# Altium schematic sheet RF.SchDoc(RF)
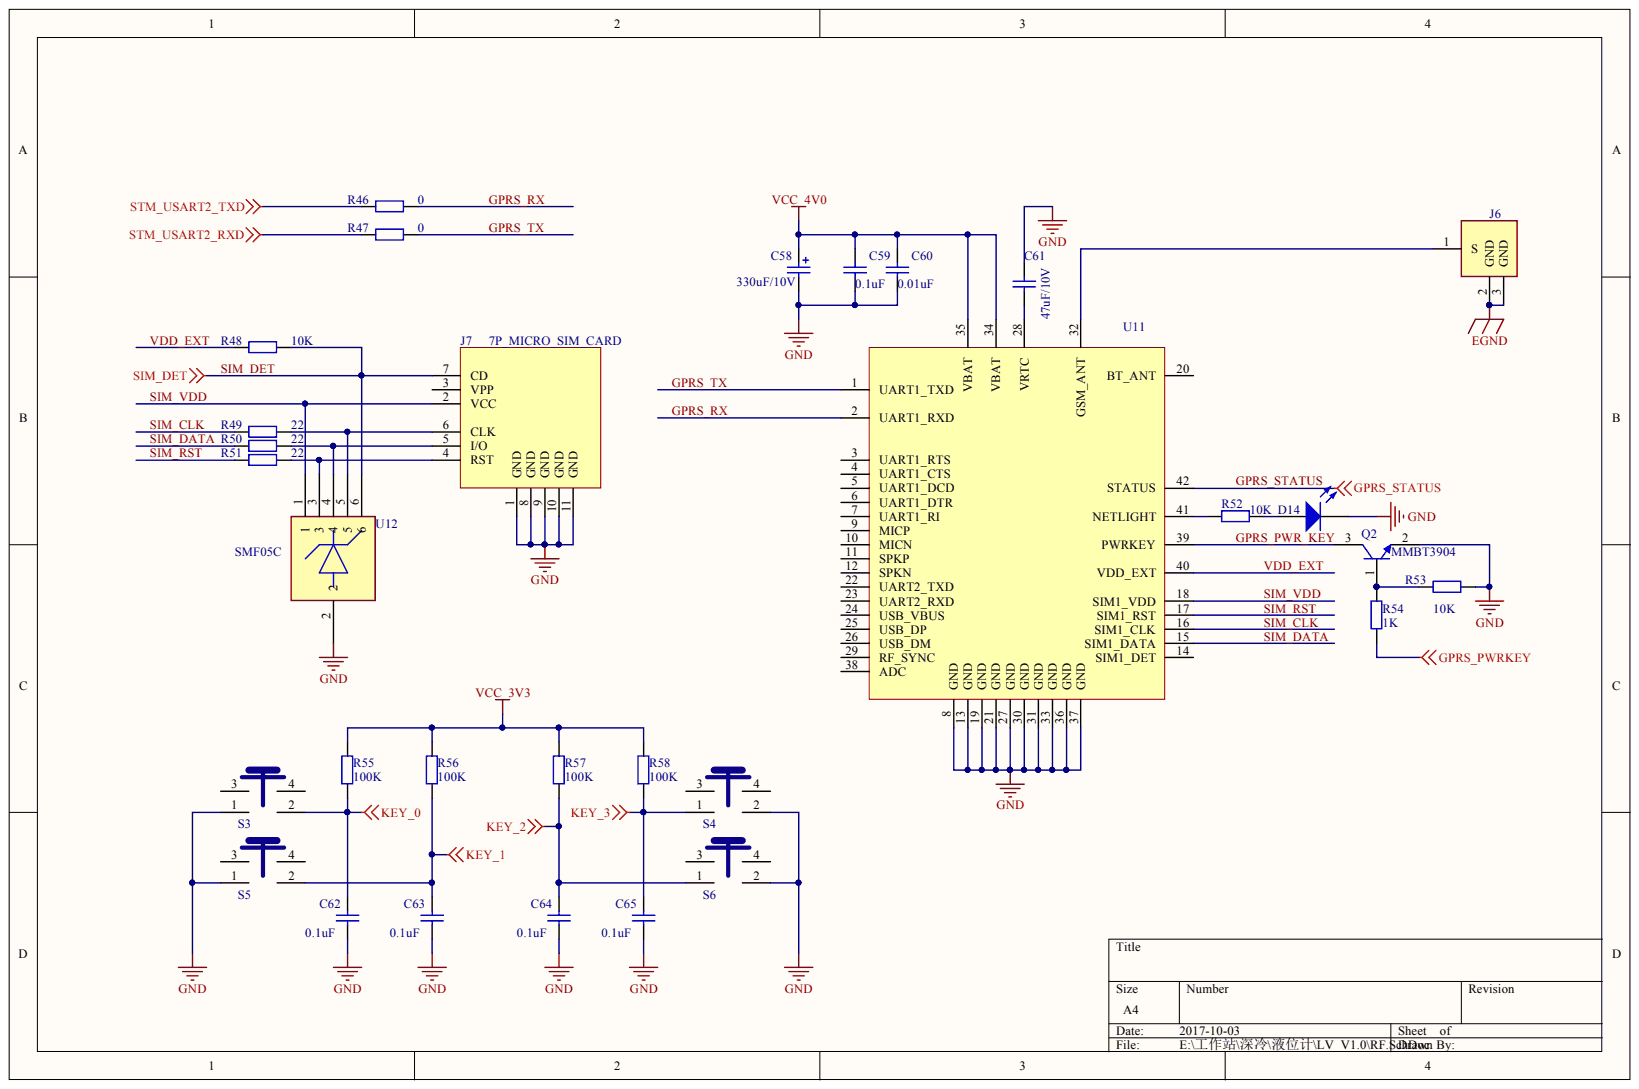
[diagram p0: RF.SchDoc]

## Parts
C58  C?  value 330uF/10V  [EIA2816P]
C59  value 0.1uF  [0603C]  (hidden comment: Capacitor)
C60  value 0.01uF  [0603C]  (hidden comment: Capacitor)
C61  value 47uF/10V  [0805C]  (hidden comment: Capacitor)
C62  value 0.1uF  [0603C]  (hidden comment: Capacitor)
C63  value 0.1uF  [0603C]  (hidden comment: Capacitor)
C64  value 0.1uF  [0603C]  (hidden comment: Capacitor)
C65  value 0.1uF  [0603C]  (hidden comment: Capacitor)
D14  LED0  [LED0805]  (value not drawn)
J6  SMA_0  [UFL_R_SMT]  (value not drawn)
J7  7P_MICRO_SIM_CARD  [MICRO SIM]
Q2  MMBT3904  [SOT23]
R46  value 0  [L0603]  (hidden comment: Resistor)
R47  value 0  [L0603]  (hidden comment: Resistor)
R48  value 10K  [L0603]  (hidden comment: Resistor)
R49  value 22  [L0603]  (hidden comment: Resistor)
R50  value 22  [L0603]  (hidden comment: Resistor)
R51  value 22  [L0603]  (hidden comment: Resistor)
R52  value 10K  [L0603]  (hidden comment: Resistor)
R53  value 10K  [L0603]  (hidden comment: Resistor)
R54  value 1K  [L0603]  (hidden comment: Resistor)
R55  value 100K  [L0603]  (hidden comment: Resistor)
R56  value 100K  [L0603]  (hidden comment: Resistor)
R57  value 100K  [L0603]  (hidden comment: Resistor)
R58  value 100K  [L0603]  (hidden comment: Resistor)
S3  KEY  [4.5X4.5X4.5]  (value not drawn)
S4  KEY  [4.5X4.5X4.5]  (value not drawn)
S5  KEY  [4.5X4.5X4.5]  (value not drawn)
S6  KEY  [4.5X4.5X4.5]  (value not drawn)
U11  SIM800C  [SIM800C]  (value not drawn)
U12  SMF05C  [SC70-6L]

## Nets
EGND: J6.2 J6.3
GND: C58.2 C59.2 C60.2 C61.1 C62.2 C63.2 C64.2 C65.2 D14.2 J7.1(GND) J7.8(GND) J7.9(GND) J7.10(GND) J7.11(GND) Q2.2 R53.2 S3.1 S4.2 S5.1 S6.2 U11.8(GND) U11.13(GND) U11.19(GND) U11.21(GND) U11.27(GND) U11.30(GND) U11.31(GND) U11.33(GND) U11.36(GND) U11.37(GND) U12.2
GPRS_PWRKEY: R54.1
KEY_0: C62.1 R55.2 S3.2
KEY_1: C63.1 R56.2 S5.2
KEY_2: C64.1 R57.2 S6.1
KEY_3: C65.1 R58.2 S4.1
STM_USART2_RXD: R47.2
STM_USART2_TXD: R46.2
VCC_3V3: R55.1 R56.1 R57.1 R58.1
VCC_4V0: C58.1 C59.1 C60.1 U11.34(VBAT) U11.35(VBAT)
GPRS_PWR_KEY: Q2.3 U11.39(PWRKEY)
GPRS_RX: R46.1 U11.2(UART1_RXD)
GPRS_STATUS: U11.42(STATUS)
GPRS_TX: R47.1 U11.1(UART1_TXD)
SIM_CLK: R49.1 U11.16(SIM1_CLK)
SIM_DATA: R50.1 U11.15(SIM1_DATA)
SIM_DET: J7.7(CD) R48.2 U12.6
SIM_RST: R51.1 U11.17(SIM1_RST)
SIM_VDD: J7.2(VCC) U11.18(SIM1_VDD) U12.1
VDD_EXT: R48.1 U11.40(VDD_EXT)
NetC61_2: C61.2 U11.28(VRTC)
NetD14_1: D14.1 R52.1
NetJ6_1: J6.1 U11.32(GSM_ANT)
NetJ7_4: J7.4(RST) R51.2 U12.3
NetJ7_5: J7.5(I/O) R50.2 U12.4
NetJ7_6: J7.6(CLK) R49.2 U12.5
NetQ2_1: Q2.1 R53.1 R54.2
NetR52_2: R52.2 U11.41(NETLIGHT)

Not connected: J7 3(VPP); S3 3 4; S4 3 4; S5 3 4; S6 3 4; U11 3(UART1_RTS) 4(UART1_CTS) 5(UART1_DCD) 6(UART1_DTR) 7(UART1_RI) 9(MICP) 10(MICN) 11(SPKP) 12(SPKN) 14(SIM1_DET) 20(BT_ANT) 22(UART2_TXD) 23(UART2_RXD) 24(USB_VBUS) 25(USB_DP) 26(USB_DM) 29(RF_SYNC) 38(ADC)

## Library data of the parts
C58 = Cap pol: Manufacturer PN: TAJA476M010R; Component Kind: Standard; Library Name: LNG.SCHLIB
C59 C60 C62-C65 = Cap: Component Kind: Standard; Description: Capacitor; LatestRevisionDate: 17-Jul-2002; LatestRevisionNote: Re-released for DXP Platform.; PackageDescription: Radial Cap, Thru-Hole; 2 Leads; 0.3 in Pin Spacing; PackageReference: RAD-0.3; Published: 8-Jun-2000; Signal Integrity: Cap; Simulation: CAP; Publisher: Altium Limited
  C59 C60: Library Name: Lib1.SCHLIB
  C62-C65: Library Name: Miscellaneous Devices.IntLib
C61 = Cap: Value: 47uF/10V; Component Kind: Standard; Description: Capacitor; LatestRevisionDate: 17-Jul-2002; LatestRevisionNote: Re-released for DXP Platform.; Library Name: Miscellaneous Devices.IntLib; PackageDescription: Radial Cap, Thru-Hole; 2 Leads; 0.3 in Pin Spacing; PackageReference: RAD-0.3; Published: 8-Jun-2000; Signal Integrity: Cap; Simulation: CAP; Publisher: Altium Limited
D14 = LED0805: Typical INFRARED GaAs LED; Value: LED_5mm_RED; Component Kind: Standard; LatestRevisionDate: 08-Jul-2005; LatestRevisionNote: Mech Layer 1 removed.; PackageDescription: LED; 2 Leads; PackageReference: LED-0; Published: 8-Jun-2000; Library Name: 简配版生物罐.SCHLIB; Publisher: Altium Limited
Q2 = NPN: Component Kind: Standard; Value: MMBT3904; Library Name: LNG.SCHLIB
R46-R58 = Res: Component Kind: Standard; Library Name: Lib1.SCHLIB
J6 = SMA_0: Component Kind: Standard; Library Name: MAINBOARD_Library.SchLib
J7 = 7P_MICRO_SIM_CARD: Component Kind: Standard; Library Name: MyLib.SCHLIB
S3-S6 = KEY: Component Kind: Standard; Value: KEY; Library Name: Bio.SchLib
U11 = SIM800C: Component Kind: Standard; Library Name: MyLib.SCHLIB
U12 = SMF05C: Component Kind: Standard; Library Name: MAINBOARD_Library.SchLib; Value: SMF05C
